# Altium schematic sheet gate_driving_slave_1.SchDoc(gate_driving_slave_1)
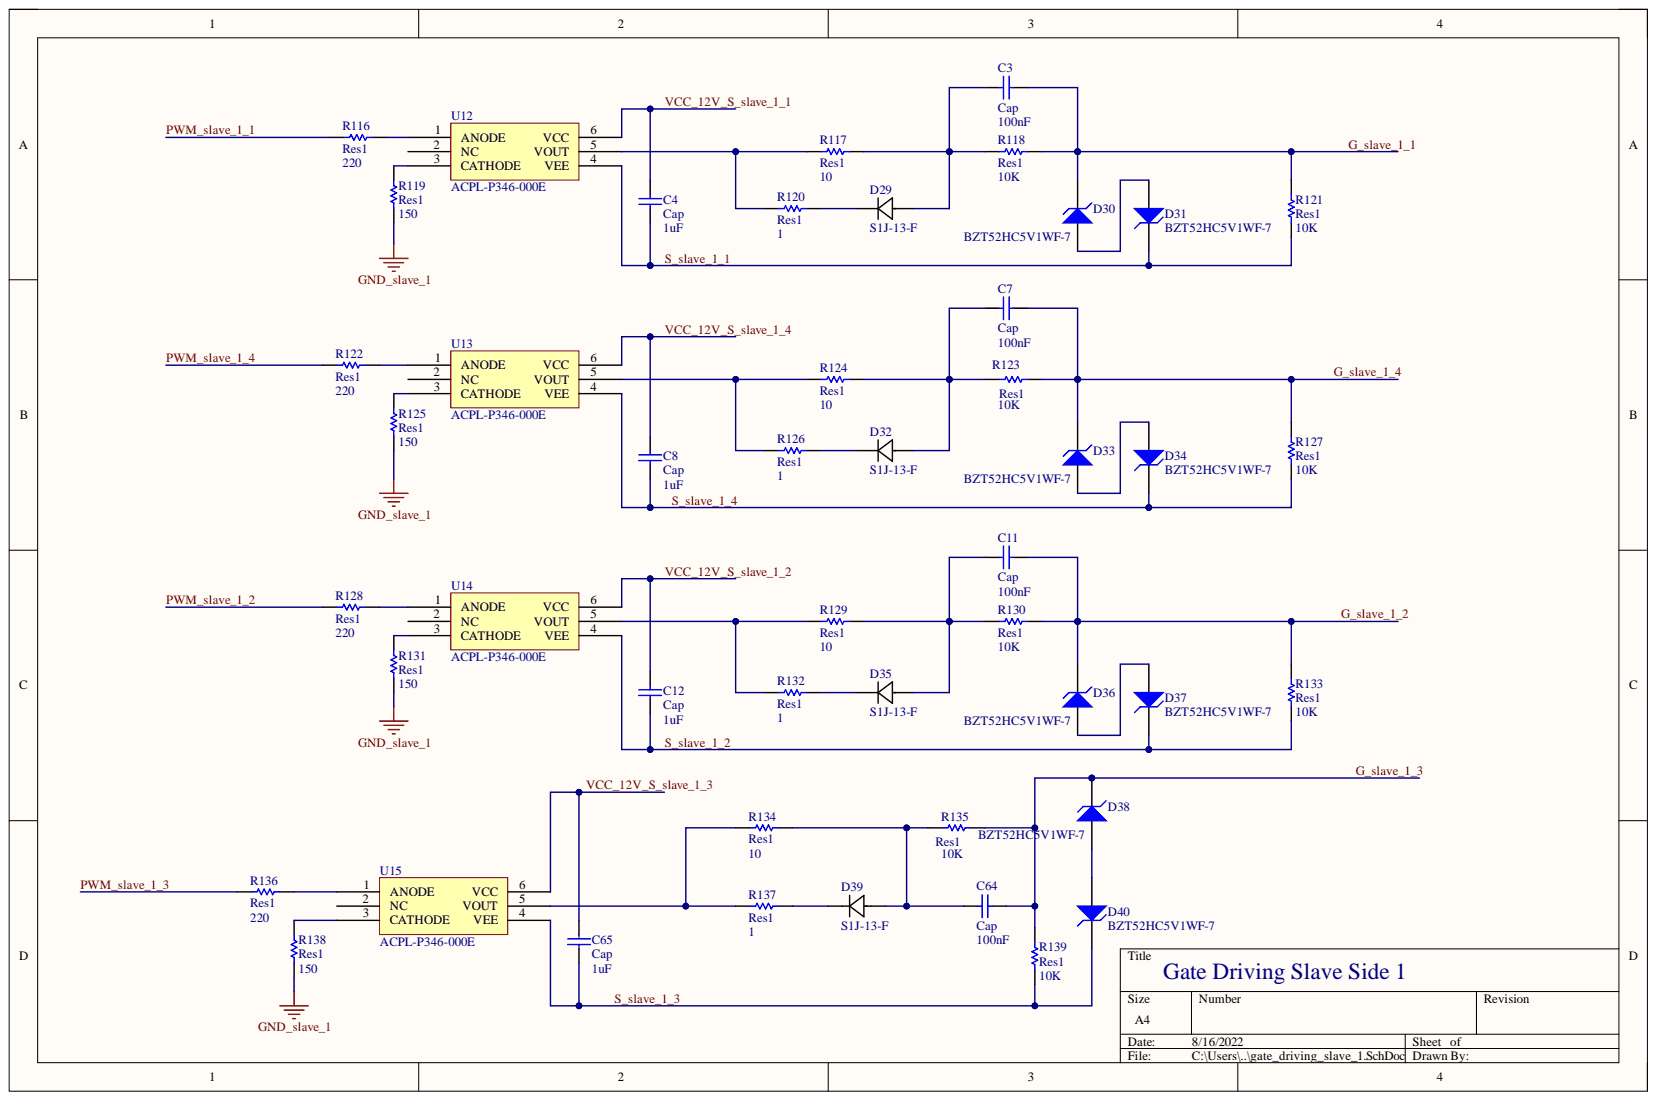
[diagram p0: gate_driving_slave_1.SchDoc]

## Parts
C3  Cap  value 100nF  [C0805]
C4  Cap  value 1uF  [C0805]
C7  Cap  value 100nF  [C0805]
C8  Cap  value 1uF  [C0805]
C11  Cap  value 100nF  [C0805]
C12  Cap  value 1uF  [C0805]
C64  Cap  value 100nF  [C0805]
C65  Cap  value 1uF  [C0805]
D29  S1J-13-F  [SMA_DIO]
D30  BZT52HC5V1WF-7  [SOD123F (Type B)]
D31  BZT52HC5V1WF-7  [SOD123F (Type B)]
D32  S1J-13-F  [SMA_DIO]
D33  BZT52HC5V1WF-7  [SOD123F (Type B)]
D34  BZT52HC5V1WF-7  [SOD123F (Type B)]
D35  S1J-13-F  [SMA_DIO]
D36  BZT52HC5V1WF-7  [SOD123F (Type B)]
D37  BZT52HC5V1WF-7  [SOD123F (Type B)]
D38  BZT52HC5V1WF-7  [SOD123F (Type B)]
D39  S1J-13-F  [SMA_DIO]
D40  BZT52HC5V1WF-7  [SOD123F (Type B)]
R116  Res1  value 220  [RES0805W]
R117  Res1  value 10  [RES0805W]
R118  Res1  value 10K  [RES0805W]
R119  Res1  value 150  [RES0805W]
R120  Res1  value 1  [RES0805W]
R121  Res1  value 10K  [RES0805W]
R122  Res1  value 220  [RES0805W]
R123  Res1  value 10K  [RES0805W]
R124  Res1  value 10  [RES0805W]
R125  Res1  value 150  [RES0805W]
R126  Res1  value 1  [RES0805W]
R127  Res1  value 10K  [RES0805W]
R128  Res1  value 220  [RES0805W]
R129  Res1  value 10  [RES0805W]
R130  Res1  value 10K  [RES0805W]
R131  Res1  value 150  [RES0805W]
R132  Res1  value 1  [RES0805W]
R133  Res1  value 10K  [RES0805W]
R134  Res1  value 10  [RES0805W]
R135  Res1  value 10K  [RES0805W]
R136  Res1  value 220  [RES0805W]
R137  Res1  value 1  [RES0805W]
R138  Res1  value 150  [RES0805W]
R139  Res1  value 10K  [RES0805W]
U12  ACPL-P346-000E  [ACPL-P346-000E]
U13  ACPL-P346-000E  [ACPL-P346-000E]
U14  ACPL-P346-000E  [ACPL-P346-000E]
U15  ACPL-P346-000E  [ACPL-P346-000E]

## Nets
GND_slave_1: R119.1 R125.1 R131.1 R138.1
G_slave_1_1: C3.1 D30.2 R118.2 R121.2
G_slave_1_2: C11.1 D36.2 R130.2 R133.2
G_slave_1_3: C64.1 D38.2 R135.2 R139.2
G_slave_1_4: C7.1 D33.2 R123.2 R127.2
PWM_slave_1_1: R116.1
PWM_slave_1_2: R128.1
PWM_slave_1_3: R136.1
PWM_slave_1_4: R122.1
S_slave_1_1: C4.1 D31.2 R121.1 U12.4(VEE)
S_slave_1_2: C12.1 D37.2 R133.1 U14.4(VEE)
S_slave_1_3: C65.1 D40.2 R139.1 U15.4(VEE)
S_slave_1_4: C8.1 D34.2 R127.1 U13.4(VEE)
VCC_12V_S_slave_1_1: C4.2 U12.6(VCC)
VCC_12V_S_slave_1_2: C12.2 U14.6(VCC)
VCC_12V_S_slave_1_3: C65.2 U15.6(VCC)
VCC_12V_S_slave_1_4: C8.2 U13.6(VCC)
NetC3_2: C3.2 D29.2 R117.2 R118.1
NetC7_2: C7.2 D32.2 R123.1 R124.2
NetC11_2: C11.2 D35.2 R129.2 R130.1
NetC64_2: C64.2 D39.2 R134.2 R135.1
NetD29_1: D29.1 R120.2
NetD30_1: D30.1 D31.1
NetD32_1: D32.1 R126.2
NetD33_1: D33.1 D34.1
NetD35_1: D35.1 R132.2
NetD36_1: D36.1 D37.1
NetD38_1: D38.1 D40.1
NetD39_1: D39.1 R137.2
NetR116_2: R116.2 U12.1(ANODE)
NetR117_1: R117.1 R120.1 U12.5(VOUT)
NetR119_2: R119.2 U12.3(CATHODE)
NetR122_2: R122.2 U13.1(ANODE)
NetR124_1: R124.1 R126.1 U13.5(VOUT)
NetR125_2: R125.2 U13.3(CATHODE)
NetR128_2: R128.2 U14.1(ANODE)
NetR129_1: R129.1 R132.1 U14.5(VOUT)
NetR131_2: R131.2 U14.3(CATHODE)
NetR134_1: R134.1 R137.1 U15.5(VOUT)
NetR136_2: R136.2 U15.1(ANODE)
NetR138_2: R138.2 U15.3(CATHODE)

Not connected: U12 2(NC); U13 2(NC); U14 2(NC); U15 2(NC)

## Library data of the parts
C3 C7 C11 = Cap: Capacitor; Value: 100nF; Component Kind: Standard; LatestRevisionDate: 17-Jul-2002; LatestRevisionNote: Re-released for DXP Platform.; Library Name: Miscellaneous Devices.IntLib; PackageDescription: Radial Cap, Thru-Hole; 2 Leads; 0.3 in Pin Spacing; PackageReference: RAD-0.3; Published: 8-Jun-2000; Signal Integrity: Cap; Simulation: CAP; Publisher: Altium Limited
C4 C8 C12 C64 C65 = Cap: Capacitor; Component Kind: Standard; LatestRevisionDate: 17-Jul-2002; LatestRevisionNote: Re-released for DXP Platform.; Library Name: Miscellaneous Devices.IntLib; PackageDescription: Radial Cap, Thru-Hole; 2 Leads; 0.3 in Pin Spacing; PackageReference: RAD-0.3; Published: 8-Jun-2000; Signal Integrity: Cap; Simulation: CAP; Publisher: Altium Limited
D29 D32 D35 D39 = S1J-13-F: No Description Available; Mfr_Name: Diodes Inc; Type: DEV; Component Kind: Standard; Digi-Key_Part_Number_1: S1J-FDICT-ND; Digi-Key_Part_Number_2: S1J-FDIDKR-ND; Digi-Key_Part_Number_3: S1J-FDITR-ND; Library Name: S1J-13-F.SchLib; Manufacturer_Part_Number: S1J-13-F; RefDes: RefDes; Copyright: Copyright (C) 2022 Ultra Librarian. All rights reserved.
D30 D31 D33 D34 D36-D38 D40 = D Zener: Zener Diode; Component Kind: Standard; LatestRevisionDate: 17-Jul-2002; LatestRevisionNote: Re-released for DXP Platform.; Library Name: Miscellaneous Devices.IntLib; PackageDescription: Diode, Thru-Hole; 2 Leads; 0.7 in Pin Spacing; PackageReference: DIODE-0.7; Published: 8-Jun-2000; Signal Integrity: D Zener; Simulation: ZENER; Publisher: Altium Limited
R116-R139 = Res1: Resistor; Component Kind: Standard; LatestRevisionDate: 17-Jul-2002; LatestRevisionNote: Re-released for DXP Platform.; Library Name: Miscellaneous Devices.IntLib; PackageDescription: Axial Device, Thru-Hole; 2 Leads; 0.3 in Pin Spacing; PackageReference: AXIAL-0.3; Published: 8-Jun-2000; Signal Integrity: Res; Simulation: RESISTOR; Publisher: Altium Limited
U12-U15 = ACPL-P346-000E: Component Kind: Standard; Library Name: ACPL-P346-000E.SchLib
